# Altium schematic sheet Sheet1.SchDoc
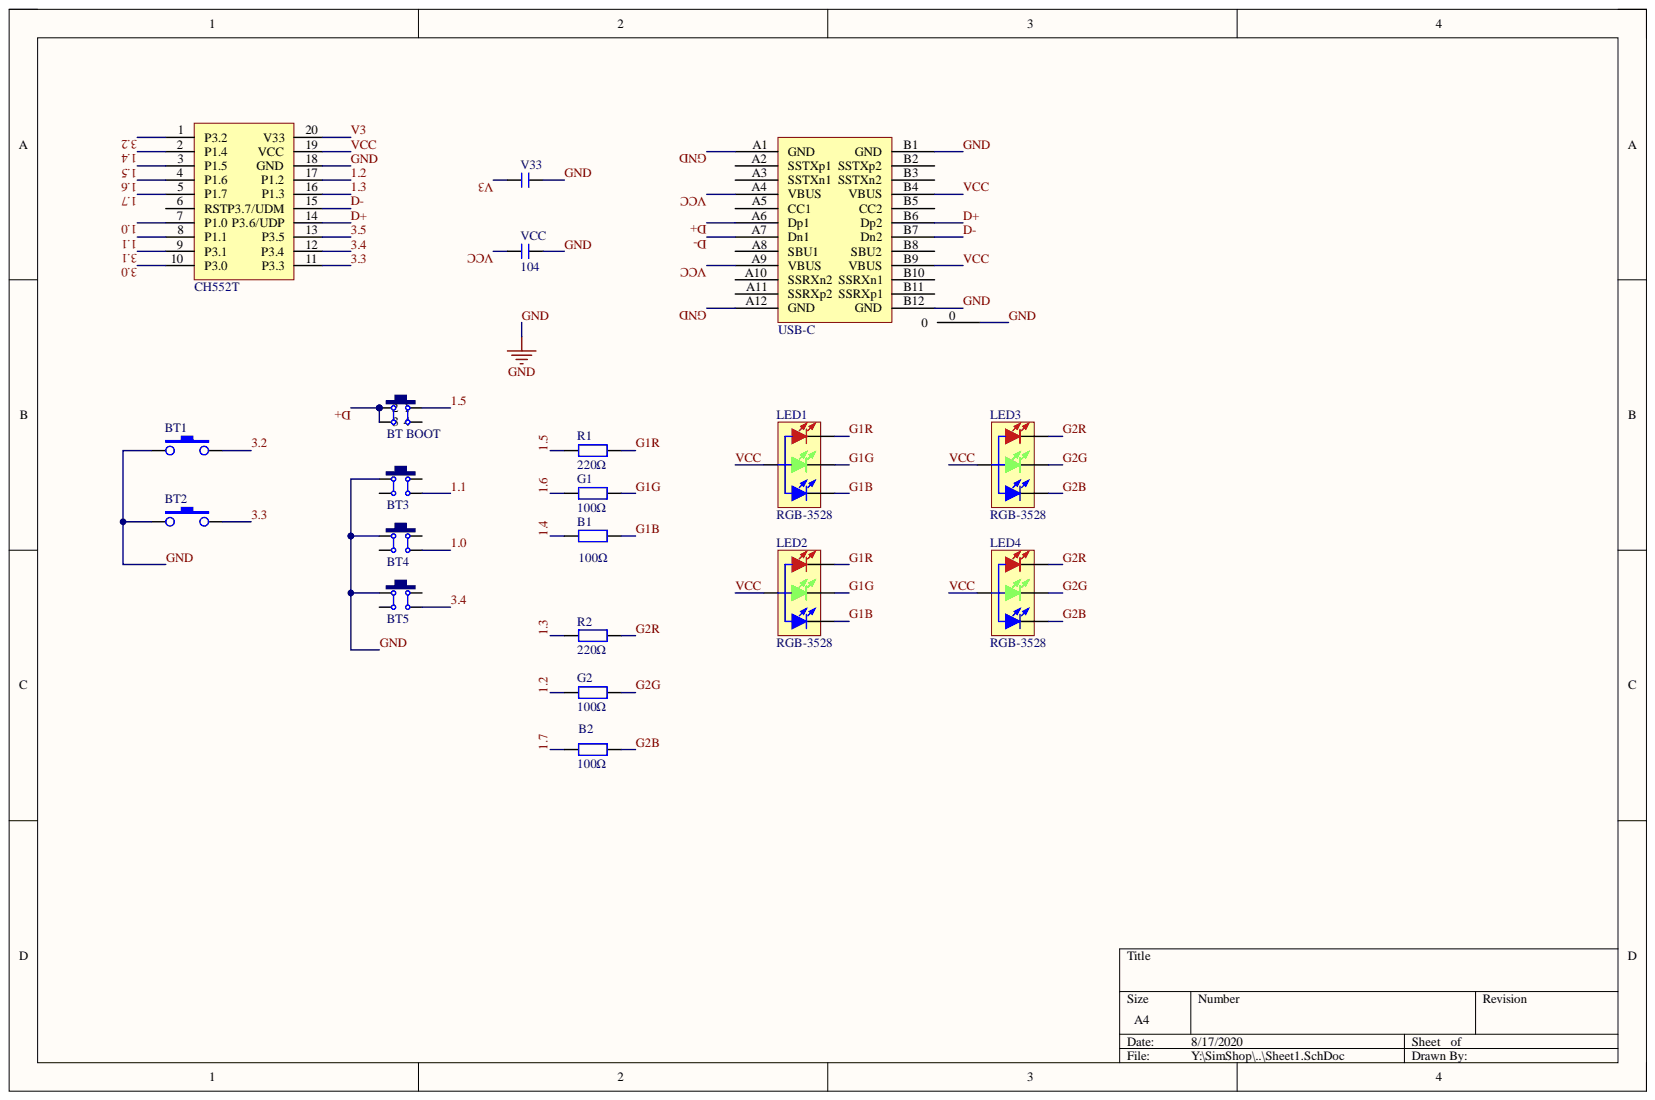
[diagram p0: Sheet1.SchDoc]

## Parts
B1  100Ω  [R 0603_L]
B2  100Ω  [R 0603_L]
BT1  MX_SW  [MX_SW-4 - duplicate]  (value not drawn)
BT2  MX_SW  [MX_SW-4 - duplicate]  (value not drawn)
BT3  6x6x5  [TSW DIP-6*6*12]  (value not drawn)
BT4  6x6x5  [TSW DIP-6*6*12]  (value not drawn)
BT5  6x6x5  [TSW DIP-6*6*12]  (value not drawn)
BT_BOOT  6x6x5  [PCBComponent_1]  (value not drawn)
CH552T  CH552T  [SSOP20_N]
G1  100Ω  [R 0603_L]
G2  100Ω  [R 0603_L]
LED1  RGB-3528  [RGB-LED]
LED2  RGB-3528  [RGB-LED]
LED3  RGB-3528  [RGB-LED]
LED4  RGB-3528  [RGB-LED]
R1  220Ω  [R 0603_L]
R2  220Ω  [R 0603_L]
USB  USB-C  [USB C F TYPE DIP+SMT CONN]
V33  104  [C 0603_L]  (value not drawn)
VCC  104  [C 0603_L]

## Nets
1.0: BT4.3 CH552T.7(P1.0)
1.1: BT3.3 CH552T.8(P1.1)
1.2: CH552T.17(P1.2) G2.1
1.3: CH552T.16(P1.3) R2.1
1.4: B1.1 CH552T.2(P1.4)
1.5: BT_BOOT.2 CH552T.3(P1.5) R1.1
1.6: CH552T.4(P1.6) G1.1
1.7: B2.1 CH552T.5(P1.7)
3.0: CH552T.10(P3.0)
3.1: CH552T.9(P3.1)
3.2: BT1.2 CH552T.1(P3.2)
3.3: BT2.2 CH552T.11(P3.3)
3.4: BT5.3 CH552T.12(P3.4)
3.5: CH552T.13(P3.5)
D+: BT_BOOT.1 BT_BOOT.4 CH552T.14(P3.6/UDP) USB.A6(Dp1) USB.B6(Dp2)
D-: CH552T.15(P3.7/UDM) USB.A7(Dn1) USB.B7(Dn2)
G1B: B1.2 LED1.3 LED2.3
G1G: G1.2 LED1.2 LED2.2
G1R: LED1.1 LED2.1 R1.2
G2B: B2.2 LED3.3 LED4.3
G2G: G2.2 LED3.2 LED4.2
G2R: LED3.1 LED4.1 R2.2
GND: BT1.1 BT2.1 BT3.1 BT4.1 BT5.1 CH552T.18(GND) USB.0 USB.A1(GND) USB.A12(GND) USB.B1(GND) USB.B12(GND) V33.2 VCC.2
V3: CH552T.20(V33) V33.1
VCC: CH552T.19(VCC) LED1.4 LED2.4 LED3.4 LED4.4 USB.A4(VBUS) USB.A9(VBUS) USB.B4(VBUS) USB.B9(VBUS) VCC.1

Not connected: BT3 2 4; BT4 2 4; BT5 2 4; BT_BOOT 3; CH552T 6(RST); USB A2(SSTXp1) A3(SSTXn1) A5(CC1) A8(SBU1) A10(SSRXn2) A11(SSRXp2) B2(SSTXp2) B3(SSTXn2) B5(CC2) B8(SBU2) B10(SSRXn1) B11(SSRXp1)

## Library data of the parts
B1 B2 G1 G2 R1 R2 = R: 贴片电阻; 版权归属: 源创客; BOM名称: 贴片电阻; Component Kind: Standard; Library Name: 3.1   - 电阻-电容-电感.SchLib; 学习资源: www.ooocke.com
BT1 BT2 = MX_SW-4: with Fixation pins  1-Pole; Component Kind: Standard; Library Name: KeyBoard.SchLib
BT3-BT5 BT_BOOT = TSW DIP-6x6: 直插6x6轻触开关; 版权归属: 源创客; BOM名称: 直插6x6轻触开关; Component Kind: Standard; Library Name: 5.1   - 按键-开关.SchLib; 学习资源: www.ooocke.com
CH552T = CH376T: USB控制器; 版权归属: 源创客; BOM名称: USB控制器; Component Kind: Standard; Library Name: 1.1   - IC芯片.SchLib; 学习资源: www.ooocke.com
LED1-LED4 = LED-RGB-3528: 三基色LED; 版权归属: 源创客; BOM名称: 三基色LED; Component Kind: Standard; Library Name: 9.1   - LED.SchLib; 学习资源: www.ooocke.com
USB = USB-C/S: USB-C型母接口; 版权归属: 源创客; BOM名称: USB-C型母接口; Component Kind: Standard; Library Name: 4.1   - 接口类.SchLib; 学习资源: www.ooocke.com
V33 VCC = C: 无极性贴片电容; 版权归属: 源创客; BOM名称: 无极性贴片电容; Component Kind: Standard; Library Name: 3.1   - 电阻-电容-电感.SchLib; 学习资源: www.ooocke.com
